# Altium schematic sheet DAC.SchDoc(DAC)
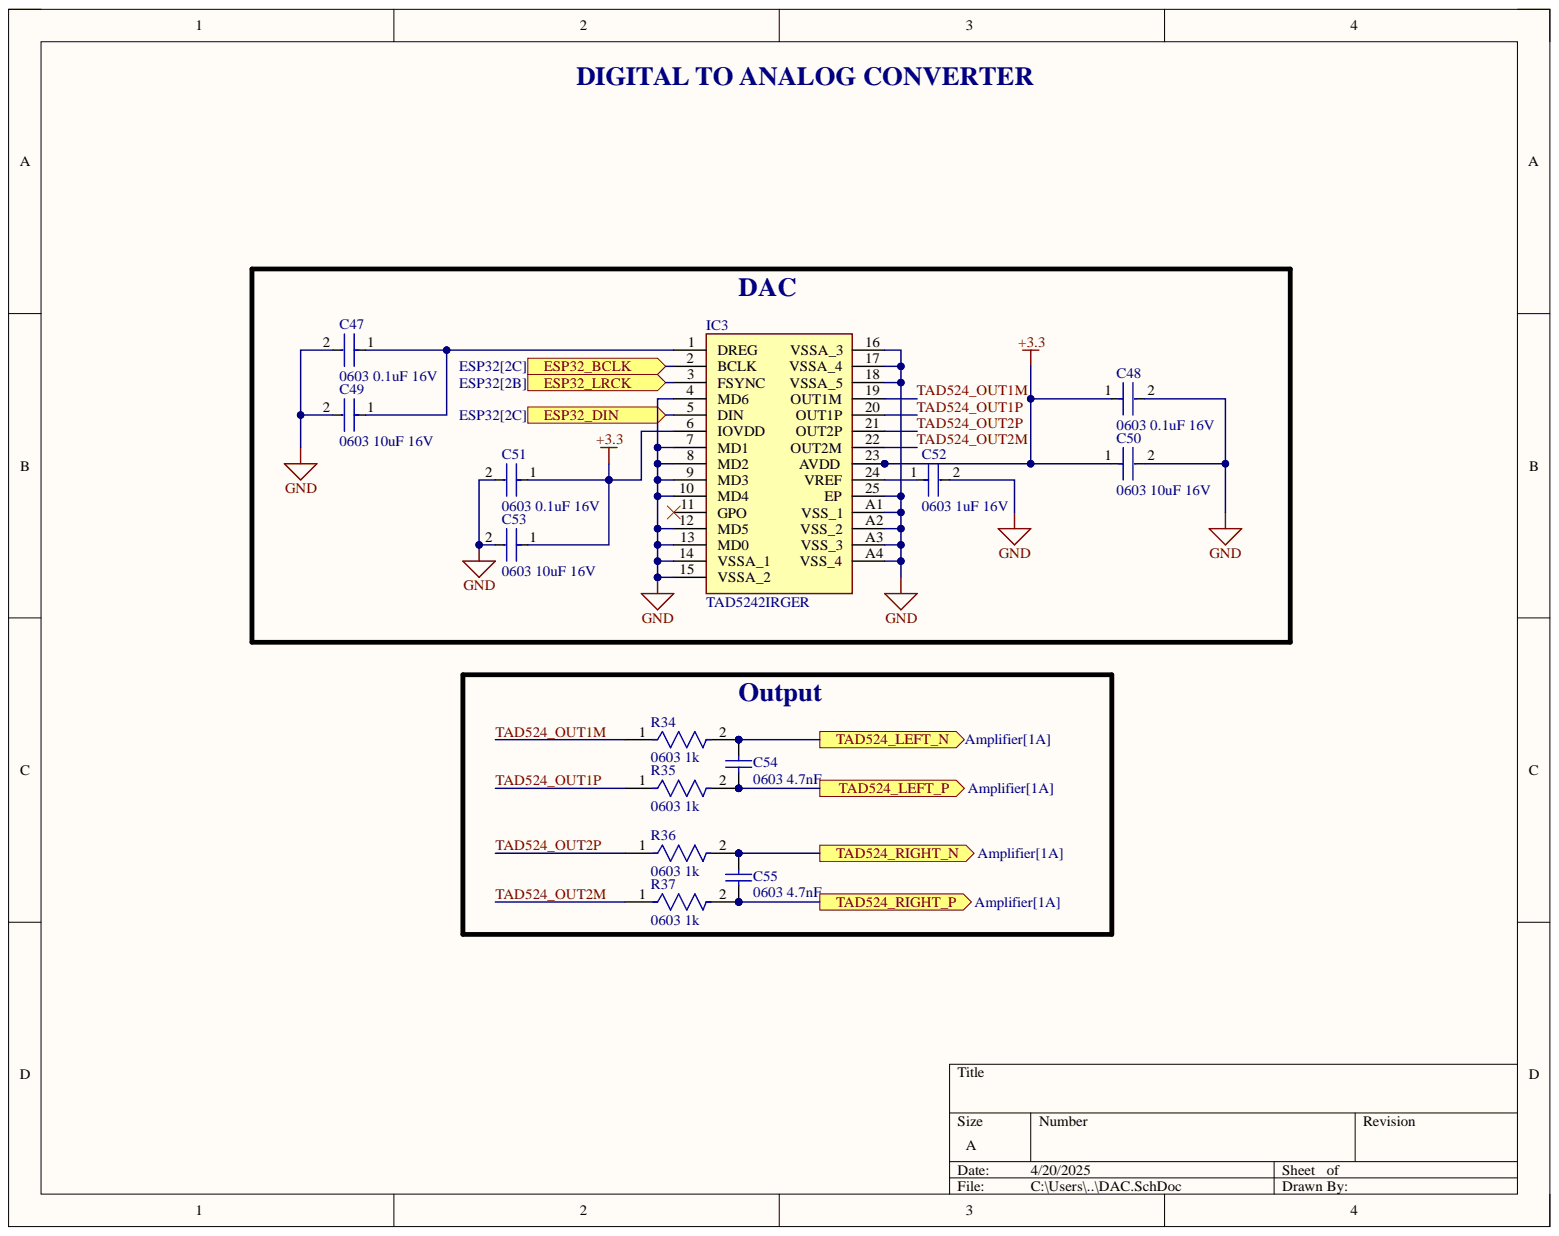
[diagram p0: DAC.SchDoc]

## Parts
C47  0603 0.1uF 16V  [FP-CC0603-DA-MFG]
C48  0603 0.1uF 16V  [FP-CC0603-DA-MFG]
C49  0603 10uF 16V  Capacitance: 10uF  Case Code (Imperial): 0603  [FP-0603-L_1_6_0_2-W_0_8_0-MFG]
C50  0603 10uF 16V  Capacitance: 10uF  Case Code (Imperial): 0603  [FP-0603-L_1_6_0_2-W_0_8_0-MFG]
C51  0603 0.1uF 16V  [FP-CC0603-DA-MFG]
C52  0603 1uF 16V  value 1 uF  [FP-CC0603-DA-MFG]
C53  0603 10uF 16V  Capacitance: 10uF  Case Code (Imperial): 0603  [FP-0603-L_1_6_0_2-W_0_8_0-MFG]
C54  0603 4.7nF  [CAPC1608X90X35ML10T15]
C55  0603 4.7nF  [CAPC1608X90X35ML10T15]
IC3  TAD5242IRGER  [TAD5242IRGER]
R34  0603 1k  Case Code (Imperial): 0603  [FP-AC0603-IPC_B]
R35  0603 1k  Case Code (Imperial): 0603  [FP-AC0603-IPC_B]
R36  0603 1k  Case Code (Imperial): 0603  [FP-AC0603-IPC_B]
R37  0603 1k  Case Code (Imperial): 0603  [FP-AC0603-IPC_B]

## Ports
ESP32_BCLK, ESP32_DIN, ESP32_LRCK, TAD524_LEFT_N, TAD524_LEFT_P, TAD524_RIGHT_N, TAD524_RIGHT_P

## Nets
+3.3: C48.1 C50.1 C51.1 C53.1 IC3.6(IOVDD) IC3.23(AVDD)
GND: C47.2 C48.2 C49.2 C50.2 C51.2 C52.2 C53.2 IC3.4(MD6) IC3.7(MD1) IC3.8(MD2) IC3.9(MD3) IC3.10(MD4) IC3.12(MD5) IC3.13(MD0) IC3.14(VSSA_1) IC3.15(VSSA_2) IC3.16(VSSA_3) IC3.17(VSSA_4) IC3.18(VSSA_5) IC3.25(EP) IC3.A1(VSS_1) IC3.A2(VSS_2) IC3.A3(VSS_3) IC3.A4(VSS_4)
ESP32_BCLK: IC3.2(BCLK) ; port ESP32_BCLK
ESP32_DIN: IC3.5(DIN) ; port ESP32_DIN
ESP32_LRCK: IC3.3(FSYNC) ; port ESP32_LRCK
TAD524_LEFT_N: C54.1 R34.2 ; port TAD524_LEFT_N
TAD524_LEFT_P: C54.2 R35.2 ; port TAD524_LEFT_P
TAD524_OUT1M: IC3.19(OUT1M) R34.1
TAD524_OUT1P: IC3.20(OUT1P) R35.1
TAD524_OUT2M: IC3.22(OUT2M) R37.1
TAD524_OUT2P: IC3.21(OUT2P) R36.1
TAD524_RIGHT_N: C55.1 R36.2 ; port TAD524_RIGHT_N
TAD524_RIGHT_P: C55.2 R37.2 ; port TAD524_RIGHT_P
NetC47_1: C47.1 C49.1 IC3.1(DREG)
NetC52_1: C52.1 IC3.24(VREF)

Not connected: IC3 11(GPO)

## Library data of the parts
C47 C48 C51 = CMP-2000-05002-2: CAP CER 0.1UF 16V X7R 0603; Manufacturer: YAGEO; Manufacturer Part Number: CC0603KRX7R7BB104; Capacitance: 100 nF; Material: Ceramic; Temperature Coefficient: 15%; Tolerance: 0.1; Value: 100 nF; Automotive: No; Category: Cap; Component Kind: Standard; ComponentLink2Description: Datasheet; ComponentLink2URL: http://www.yageo.com/documents/recent/UPY-GPHC_X7R_6.3V-to-50V_18.pdf; Device Class L1: Passive Components; Device Class L2: Capacitors; Device Class L3: Ceramic Capacitors; Digikey Description: CAP CER 0.1UF 16V X7R 0603; Footprint Url: http://www.yageo.com/exep/pages/download/literatures/UPY-C_GEN_24.pdf; Height: 0.9mm; Lead Free: Yes; Library Name: Altium Content Vault; Mouser Description: Multilayer Ceramic Capacitor, CC Series, 0.1 - F, - 10%, X7R, 16 V, 0603 [1608 Metric]; Octopart: https://octopart.com/cc0603krx7r7bb104-yageo-192941; Package: CC0603-DA; RoHS: TRUE; Temperature: -55°C to +125°C; Temperature Characteristic: X7R; Temperature Range High: +125°C; Temperature Range Low: -55°C; Voltage: 16 V; Voltage Rating: 16 V
C49 C50 C53 = CMP-2000-06226-4: Multilayer Ceramic Capacitor 10uF 16V X5R 20% SMD 0603 T/R; Case Code (Metric): 1608; Case/Package: 0603; Component Kind: Standard; Construction: Flat; Dielectric: X5R; Halogen Free: Halogen Free; Height: 0.8mm; Length: 0.063inch; Library Name: Altium Content Vault; Max Operating Temperature: 85°C; Min Operating Temperature: -55°C; Mount: Surface Mount; Package Quantity: 1; Packaging: Tape and Reel; RoHS Compliant: Yes; Series: M; Termination: SMD/SMT; Thickness: 0.031inch; Tolerance: 20%; Voltage Rating: 16V; Voltage Rating (DC): 16V; Width: 0.031inch
C52 = CMP-03422-000924-1: CAP CER 1UF 16V X7R 0603; Manufacturer: YAGEO; Manufacturer Part Number: CC0603KRX7R7BB105; Material: Ceramic; Temperature Coefficient: 15%; Tolerance: 0.1; Automotive: No; Capacitance: 1 uF; Category: Cap; Component Kind: Standard; ComponentLink2Description: Datasheet; ComponentLink2URL: http://www.yageo.com/documents/recent/UPY-GPHC_X7R_6.3V-to-50V_18.pdf; Device Class L1: Passive Components; Device Class L2: Capacitors; Device Class L3: Ceramic Capacitors; Digikey Description: CAP CER 1UF 16V X7R 0603; Footprint Url: http://www.yageo.com/exep/pages/download/literatures/UPY-C_GEN_24.pdf; Height: 0.9mm; Lead Free: Yes; Library Name: Altium Content Vault; Mouser Description: Multilayer Ceramic Capacitor, CC Series, 1 - F, - 10%, X7R, 16 V, 0603 [1608 Metric]; Octopart: https://octopart.com/cc0603krx7r7bb105-yageo-42271928; Package: CC0603-DA; RoHS: TRUE; Temperature: -55°C to +125°C; Temperature Characteristic: X7R; Temperature Range High: +125°C; Temperature Range Low: -55°C; Value: 1 uF; Voltage: 16 V; Voltage Rating: 16 V
C54 C55 = CMP-2006-02924-1: Manufacturer: Murata; Part Number: GRM188R71H472KA01D; Component Kind: Standard; Library Name: Altium Content Vault
IC3 = TAD5242IRGER: Integrated Circuit; Manufacturer_Name: Texas Instruments; Component Kind: Standard; Datasheet Link: https://www.ti.com/lit/ds/symlink/tad5242.pdf?ts=1736249226355&ref_url=https%253A%252F%252Fwww.ti.com%252Fproduct%252FTAD5242; Height: 1mm; Manufacturer_Part_Number: TAD5242IRGER; Mouser Part Number: 595-TAD5242IRGER; Mouser Price/Stock: https://www.mouser.co.uk/ProductDetail/Texas-Instruments/TAD5242IRGER?qs=%252BXxaIXUDbq07iiss%252B1zA7A%3D%3D
R34-R37 = CMP-2000-04828-3: RES 1kΩ 1.00% 0.1W 0603; Max Power Dissipation: 100mW; Resistance: 1kR; Temperature Coefficient: 100ppm/°C; Tolerance: 1%; Voltage Rating: 50V; Case Code (Metric): 1608; Case/Package: 0603; Component Kind: Standard; Construction: Rectangular; Depth: 0.8mm; Features: Moisture Resistant; Height: 0.022inch; Lead Free: Lead Free; Length: 0.063inch; Library Name: Altium Content Vault; Max Operating Temperature: 155°C; Military Standard: Not; Min Operating Temperature: -55°C; Number of Terminations: 2; Package Quantity: 1; Packaging: Tape and Reel; Power Rating: 100mW; Ratings: AEC-Q200; Resistor Type: Thick Film; RoHS Compliant: Yes; Series: AC; Size Code: 0603; Termination: SMD/SMT; Width: 0.031inch
